# Altium schematic sheet Sheet1.SchDoc
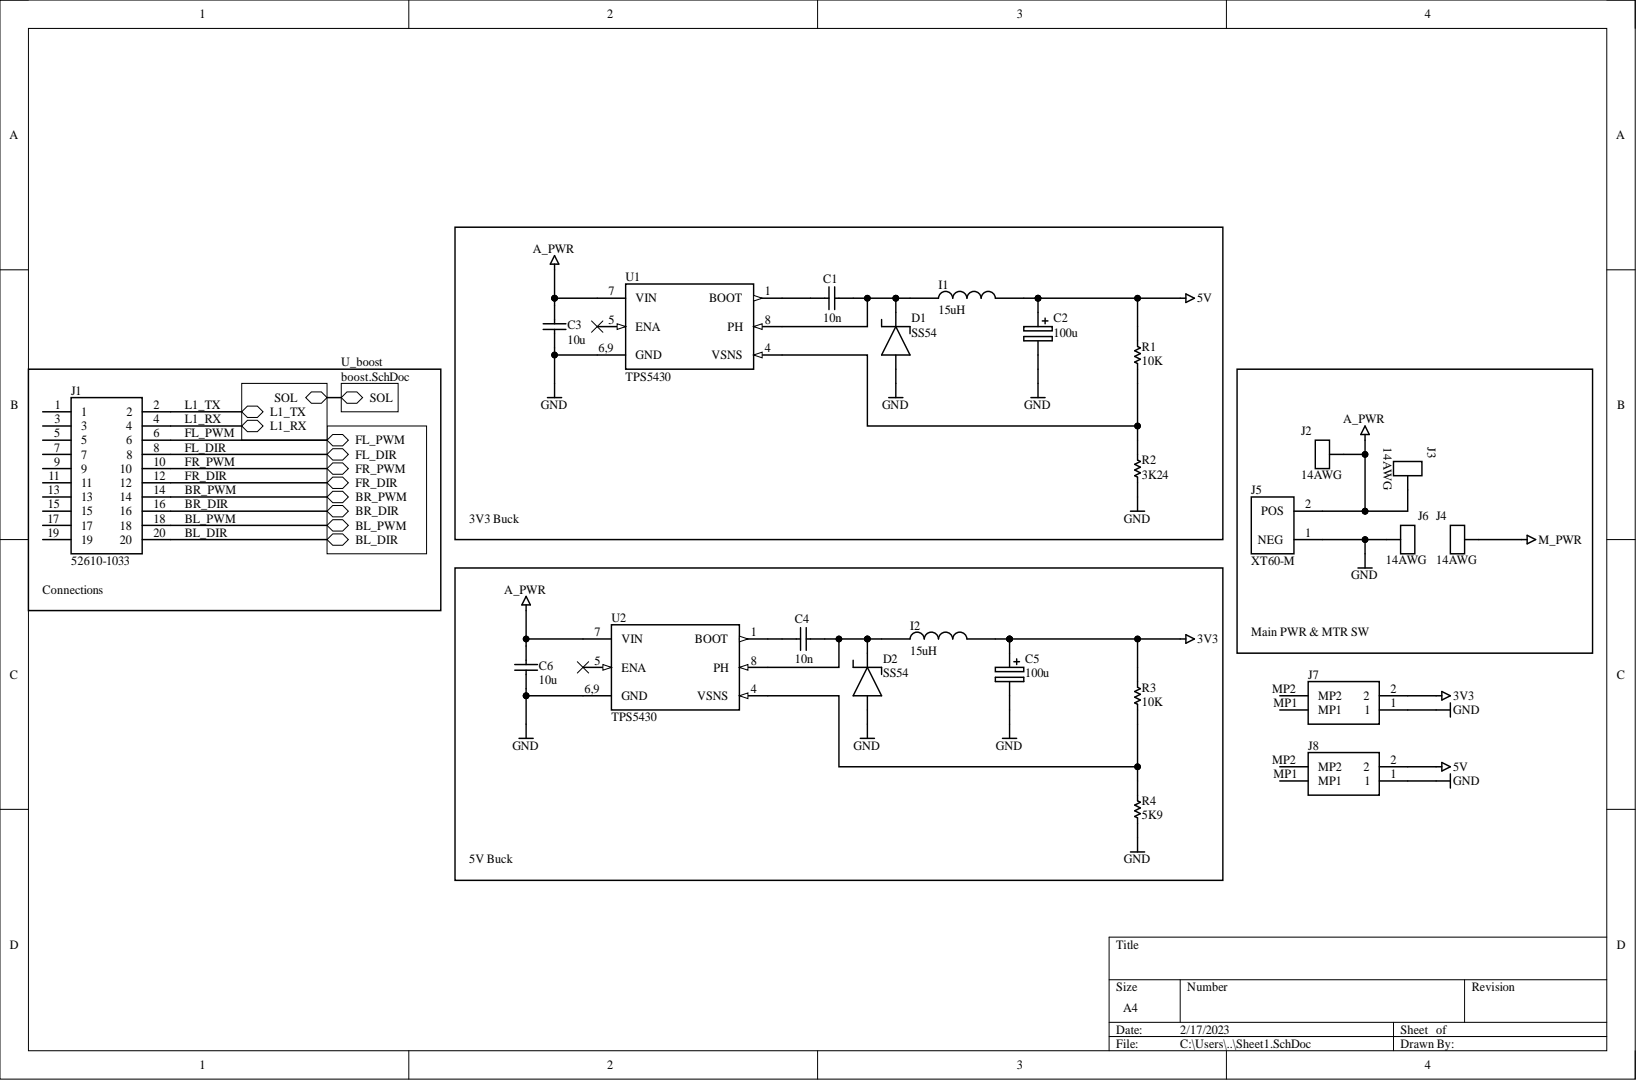
[diagram p0: Sheet1.SchDoc]

## Parts
C1  10n  [CAP0603]
C2  100u  [CAP7343]
C3  10u  [CAP0603]
C4  10n  [CAP0603]
C5  100u  [CAP7343]
C6  10u  [CAP0603]
D1  SS54  [SMDSC]
D2  SS54  [SMDSC]
I1  15uH  [SWPA8040]
I2  15uH  [SWPA8040]
J1  52610-1033  [526101033]
J2  14AWG  [14AWG]
J3  14AWG  [14AWG]
J4  14AWG  [14AWG]
J5  XT60-M  [AMASS_XT60-M]
J6  14AWG  [14AWG]
J7  BM02B-SRSS-TB_LF__SN_  [BM02BSRSSTBLFSN]  (value not drawn)
J8  BM02B-SRSS-TB_LF__SN_  [BM02BSRSSTBLFSN]  (value not drawn)
R1  10K  [RES0603]
R2  3K24  [RES0603]
R3  10K  [RES0603]
R4  5K9  [RES0603]
U1  TPS5430  [FP-DDA0008J-MFG]
U2  TPS5430  [FP-DDA0008J-MFG]

## Nets
3V3: C5.1 I2.2 J7.2 R3.1
5V: C2.1 I1.2 J8.2 R1.1
A_PWR: C3.2 C6.2 J2.1 J3.1 J5.2 U1.7(VIN) U2.7(VIN)
GND: C2.2 C3.1 C5.2 C6.1 D1.2 D2.2 J5.1 J6.1 J7.1 J8.1 R2.2 R4.2 U1.6,9(GND) U2.6,9(GND)
M_PWR: J4.1
BL_DIR: J1.20
BL_PWM: J1.18
BR_DIR: J1.16
BR_PWM: J1.14
FL_DIR: J1.8
FL_PWM: J1.6
FR_DIR: J1.12
FR_PWM: J1.10
L1_RX: J1.4
L1_TX: J1.2
NetC1_1: C1.1 D1.1 I1.1 U1.8(PH)
NetC1_2: C1.2 U1.1(BOOT)
NetC4_1: C4.1 D2.1 I2.1 U2.8(PH)
NetC4_2: C4.2 U2.1(BOOT)
NetJ1_1: J1.1
NetJ1_3: J1.3
NetJ1_5: J1.5
NetJ1_7: J1.7
NetJ1_9: J1.9
NetJ1_11: J1.11
NetJ1_13: J1.13
NetJ1_15: J1.15
NetJ1_17: J1.17
NetJ1_19: J1.19
NetJ7_MP1: J7.MP1
NetJ7_MP2: J7.MP2
NetJ8_MP1: J8.MP1
NetJ8_MP2: J8.MP2
NetR1_2: R1.2 R2.1 U1.4(VSNS)
NetR3_2: R3.2 R4.1 U2.4(VSNS)
NetU1_5: U1.5(ENA)
NetU2_5: U2.5(ENA)
SOL:

## Library data of the parts
C1 C3 C4 C6 = CAP0603: 0603 Capacitor; Component Kind: Standard; Library Name: Integrated_Library1.IntLib
C2 C5 = EIA7343: 25V 100UF ±20%; Component Kind: Standard; Library Name: Integrated_Library1.IntLib; Value: 100u
D1 D2 = Schotty Diode: SMD Schotty Diode; Component Kind: Standard; Library Name: Integrated_Library1.IntLib
I1 I2 = SWPA8040: 10uH INDUCTOR; Component Kind: Standard; Library Name: Integrated_Library1.IntLib
J1 = 52610-1033: Connector; Manufacturer_Name: Molex; Component Kind: Standard; Datasheet Link: https://www.mouser.com/datasheet/2/276/0526101033_FFC_FPC_CONNECTORS-294979.pdf; Height: 7.45mm; Library Name: Integrated_Library1.IntLib; Manufacturer_Part_Number: 52610-1033; Mouser Part Number: 538-52610-1033; Mouser Price/Stock: https://www.mouser.co.uk/ProductDetail/Molex/52610-1033?qs=rw2VfpiI%2FsPpjB%252BOP20huA%3D%3D
J5 = CMP-062-000000-4: Plug; DC supply; XT60; male; PIN: 2; for cable; soldered; 30A; 500V; MF: AMASS; Availability: Unavailable; Component Kind: Standard; Component Type: Standard; Design Item ID: XT60-M; MP: XT60-M; Package: Package Analog Devices; Pin Count: 2; Price: None; SnapEDA_Link: https://www.snapeda.com/parts/XT60-M/AMASS/view-part/2883912/?ref=snap; Source: SnapEDAsch.SchLib; Library Name: Hwa Chong Institution
J7 J8 = BM02B-SRSS-TB_LF__SN_: Connector; Manufacturer_Name: JST (JAPAN SOLDERLESS TERMINALS); Manufacturer_Part_Number: BM02B-SRSS-TB(LF)(SN); Arrow Part Number: BM02B-SRSS-TB(LF)(SN); Arrow Price/Stock: https://www.arrow.com/en/products/bm02b-srss-tb-lf-sn/jst-manufacturing?region=europe; Component Kind: Standard; Datasheet Link: http://www.jst-mfg.com/product/pdf/eng/eSR.pdf; Height: 4.25mm; Library Name: Integrated_Library1.IntLib
R1-R4 = RES0603: Resistor; Component Kind: Standard; Library Name: Integrated_Library1.IntLib
U1 U2 = TPS5430: TPS5430 3-A, Wide Input Range, Step-Down Converter; Component Kind: Standard; Library Name: Integrated_Library1.IntLib
J2-J4 J6 = 14AWG: Component Kind: Standard; Library Name: Integrated_Library1.IntLib
